# Altium schematic sheet ant2-usb-c-lipo-charger.SchDoc(ant2-usb-c-lipo-charger)
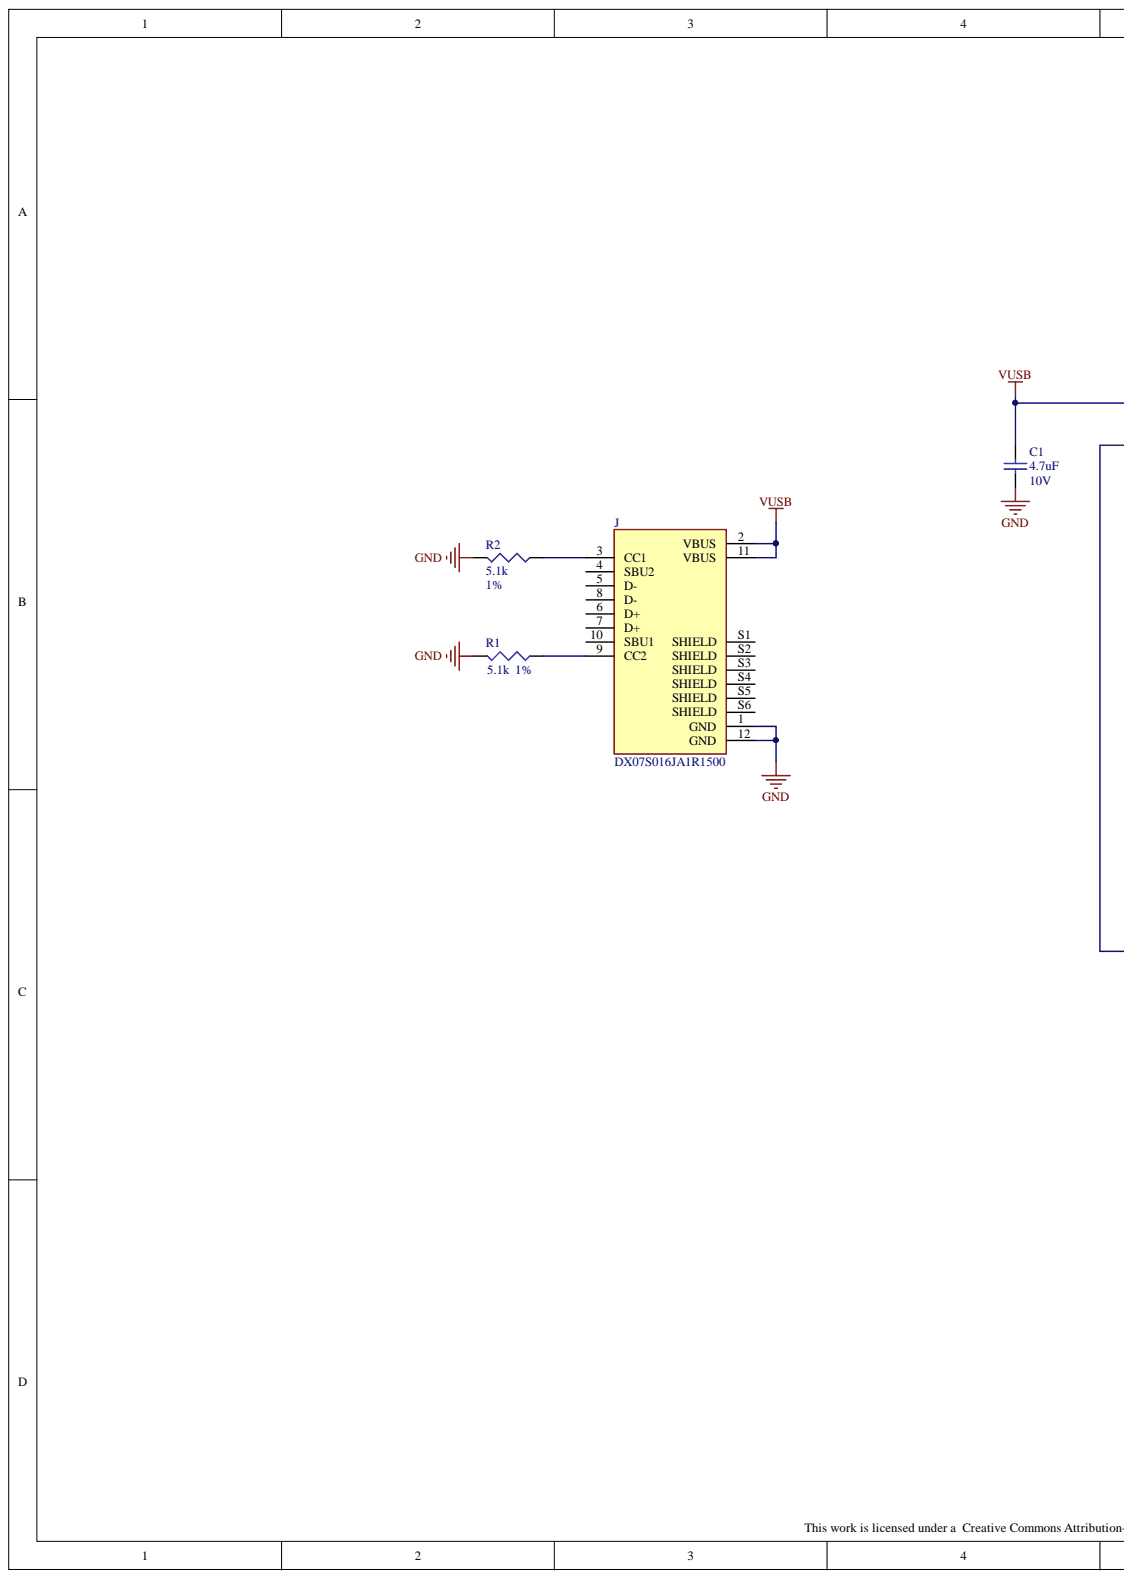
[diagram p0: ant2-usb-c-lipo-charger.SchDoc, left]
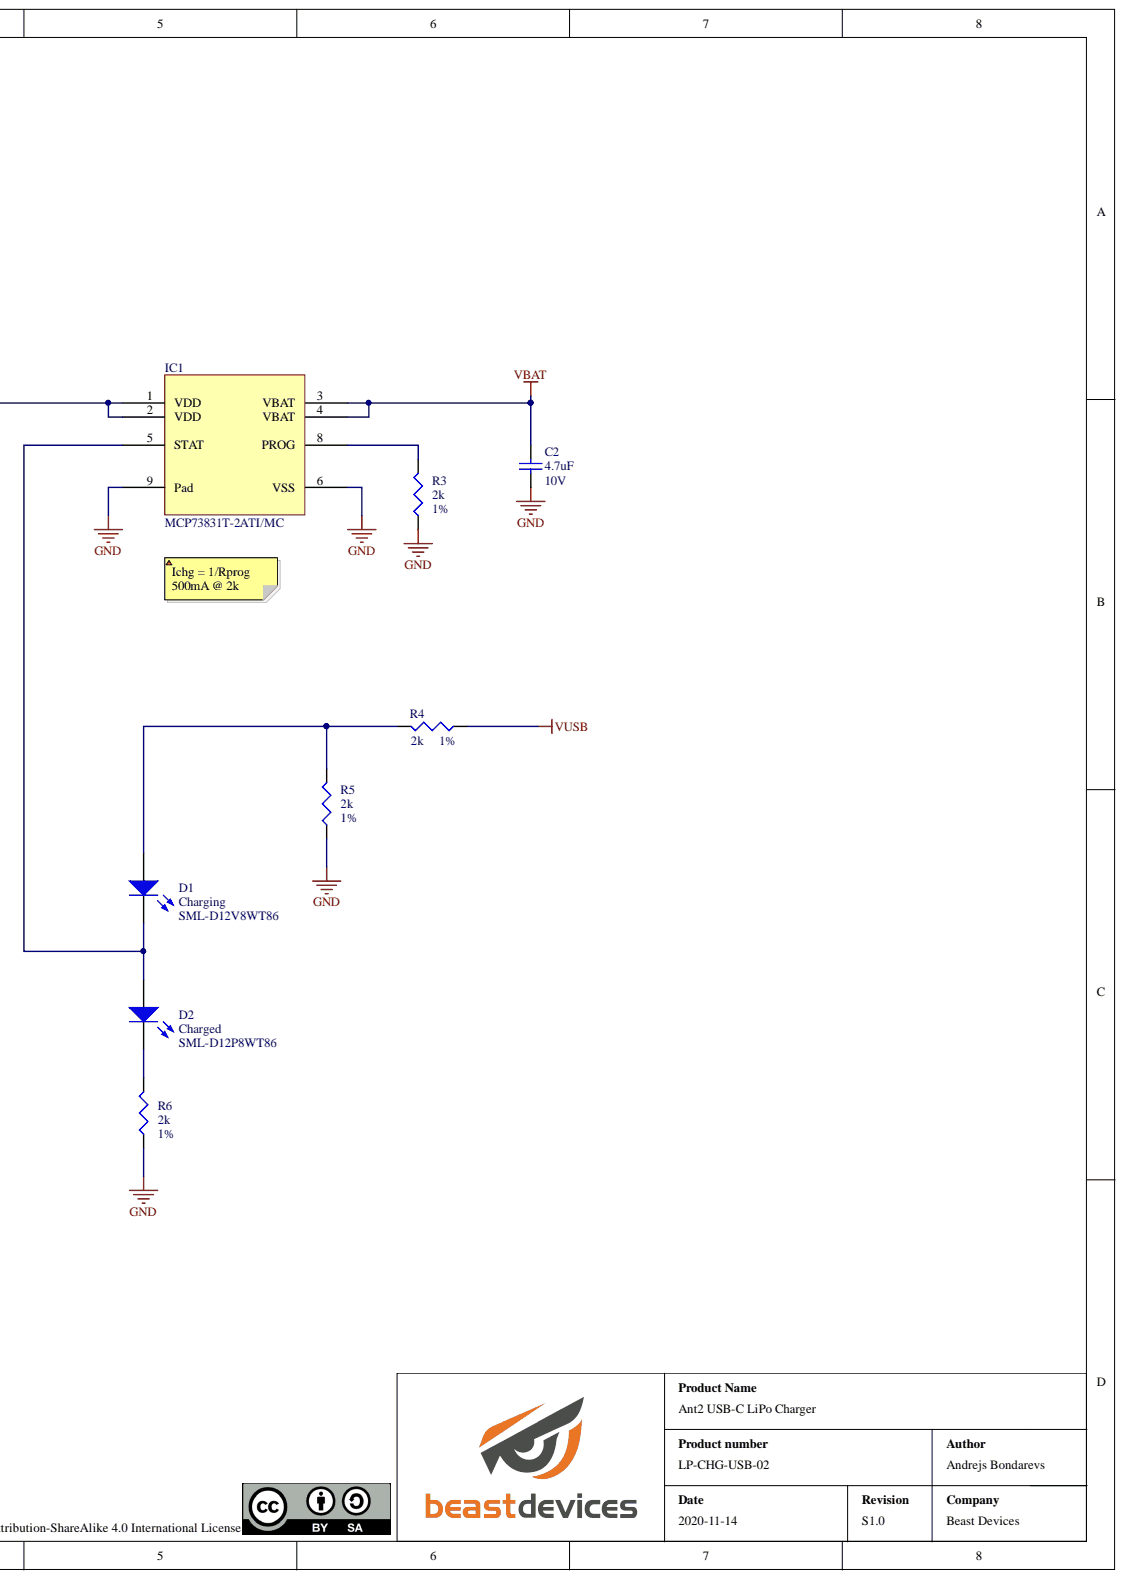
[diagram p1: ant2-usb-c-lipo-charger.SchDoc, right]

## Parts
C1  value 4.7uF  Voltage: 10V  [1608[0603]]  (hidden comment: Cap)
C2  value 4.7uF  Voltage: 10V  [1608[0603]]  (hidden comment: Cap)
D1  Charging  Part Number: SML-D12V8WT86  [LED0603]
D2  Charged  Part Number: SML-D12P8WT86  [LED0603]
IC1  MCP73831  Part Number: MCP73831T-2ATI/MC  [DFN2x3_8Lead]
J  DX07S016JA1R1500  [JAE_DX07S016JA1R1500]
R1  value 5.1k  Tolerance: 1%  [J1-0603]  (hidden comment: Res3)
R2  value 5.1k  Tolerance: 1%  [J1-0603]  (hidden comment: Res3)
R3  value 2k  Tolerance: 1%  [J1-0603]  (hidden comment: Res3)
R4  value 2k  Tolerance: 1%  [J1-0603]
R5  value 2k  Tolerance: 1%  [J1-0603]
R6  value 2k  Tolerance: 1%  [J1-0603]

## Nets
GND: C1.1 C2.1 IC1.6(VSS) IC1.9(Pad) J.1(GND) J.12(GND) R1.2 R2.1 R3.1 R5.1 R6.1
VBAT: C2.2 IC1.3(VBAT) IC1.4(VBAT)
VUSB: C1.2 IC1.1(VDD) IC1.2(VDD) J.2(VBUS) J.11(VBUS) R4.1
NetD1_1: D1.1 R4.2 R5.2
NetD1_2: D1.2 D2.1 IC1.5(STAT)
NetD2_2: D2.2 R6.2
NetIC1_8: IC1.8(PROG) R3.2
NetJ_3: J.3(CC1) R2.2
NetJ_9: J.9(CC2) R1.1

Not connected: J 4(SBU2) 5(D-) 6(D+) 7(D+) 8(D-) 10(SBU1) S1(SHIELD) S2(SHIELD) S3(SHIELD) S4(SHIELD) S5(SHIELD) S6(SHIELD)

## Library data of the parts
C1 C2 = Cap Semi: Capacitor (Semiconductor SIM Model); Manufacturer: TDK; Part Number: C1608X5R1A475K080AE; Dielectric: X5R; Tolerance: 10%; Component Kind: Standard; Library Name: Miscellaneous Devices.IntLib; Simulation: CAP
  C1: Published: 8-Jun-2000
D1 = LED2: 0603 SMD LED RED; Manufacturer: ROHM Semiconductor; Component Kind: Standard; Library Name: Miscellaneous Devices.IntLib; Simulation: LED2
D2 = LED2: 0603 SMD LED Green; Manufacturer: ROHM Semiconductor; Component Kind: Standard; Library Name: Miscellaneous Devices.IntLib; Simulation: LED2
IC1 = MCP73831: Manufacturer: Microchip; Component Kind: Standard; Library Name: my_sch_lib.SchLib
J = DX07S016JA1R1500: USB-C (USB TYPE-C) USB 2.0 Receptacle Connector 24 (16+8 Dummy) Position Surface Mount, Right Angle; Through Hole; Manufacturer: JAE Electronics; Availability: Unavailable; Component Kind: Standard; DigiKey-Purchase-URL: https://snapeda.com/shop?store=DigiKey&id=4551013; Library Name: DX07S016JA1R1500.IntLib; Package: None; Part Number: DX07S016JA1R1500; Price: None; SnapEDA_Link: https://www.snapeda.com/parts/DX07S016JA1R1500/JAE%20Electronics/view-part/4551013/?ref=snap
R1 R2 = Res3: Resistor; Manufacturer: Yageo; Part Number: RC0603FR-075K1L; Component Kind: Standard; Library Name: Miscellaneous Devices.IntLib; Simulation: RESISTOR
R3 R5 R6 = Res3: Resistor; Manufacturer: Yageo; Part Number: RC0603FR-072KL; Component Kind: Standard; Library Name: Miscellaneous Devices.IntLib; Simulation: RESISTOR
R4 = Res3: Resistor; Manufacturer: Vishay; Part Number: RC0603FR-072KL; Component Kind: Standard; Library Name: Miscellaneous Devices.IntLib; Simulation: RESISTOR
